# Altium schematic sheet 05_CONNECTOR_OUT.SchDoc(05_CONNECTOR_OUT)
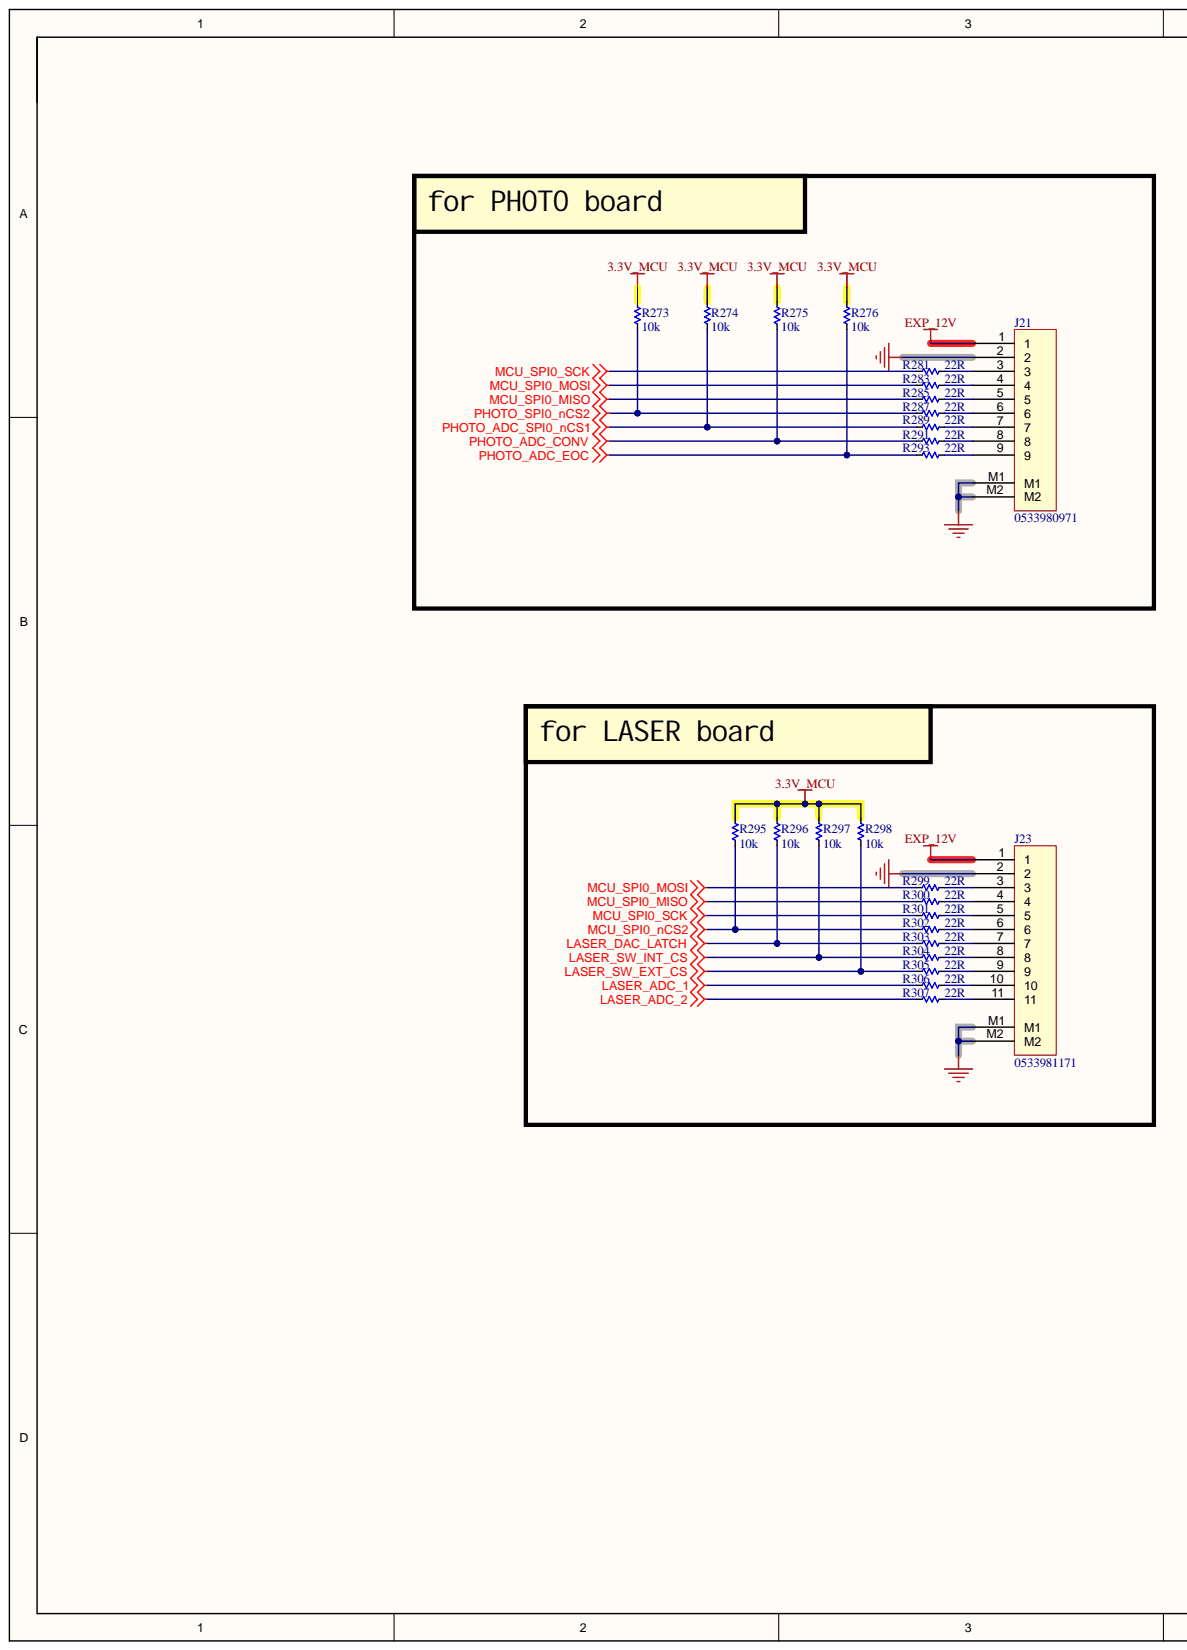
[diagram p0: 05_CONNECTOR_OUT.SchDoc, left]
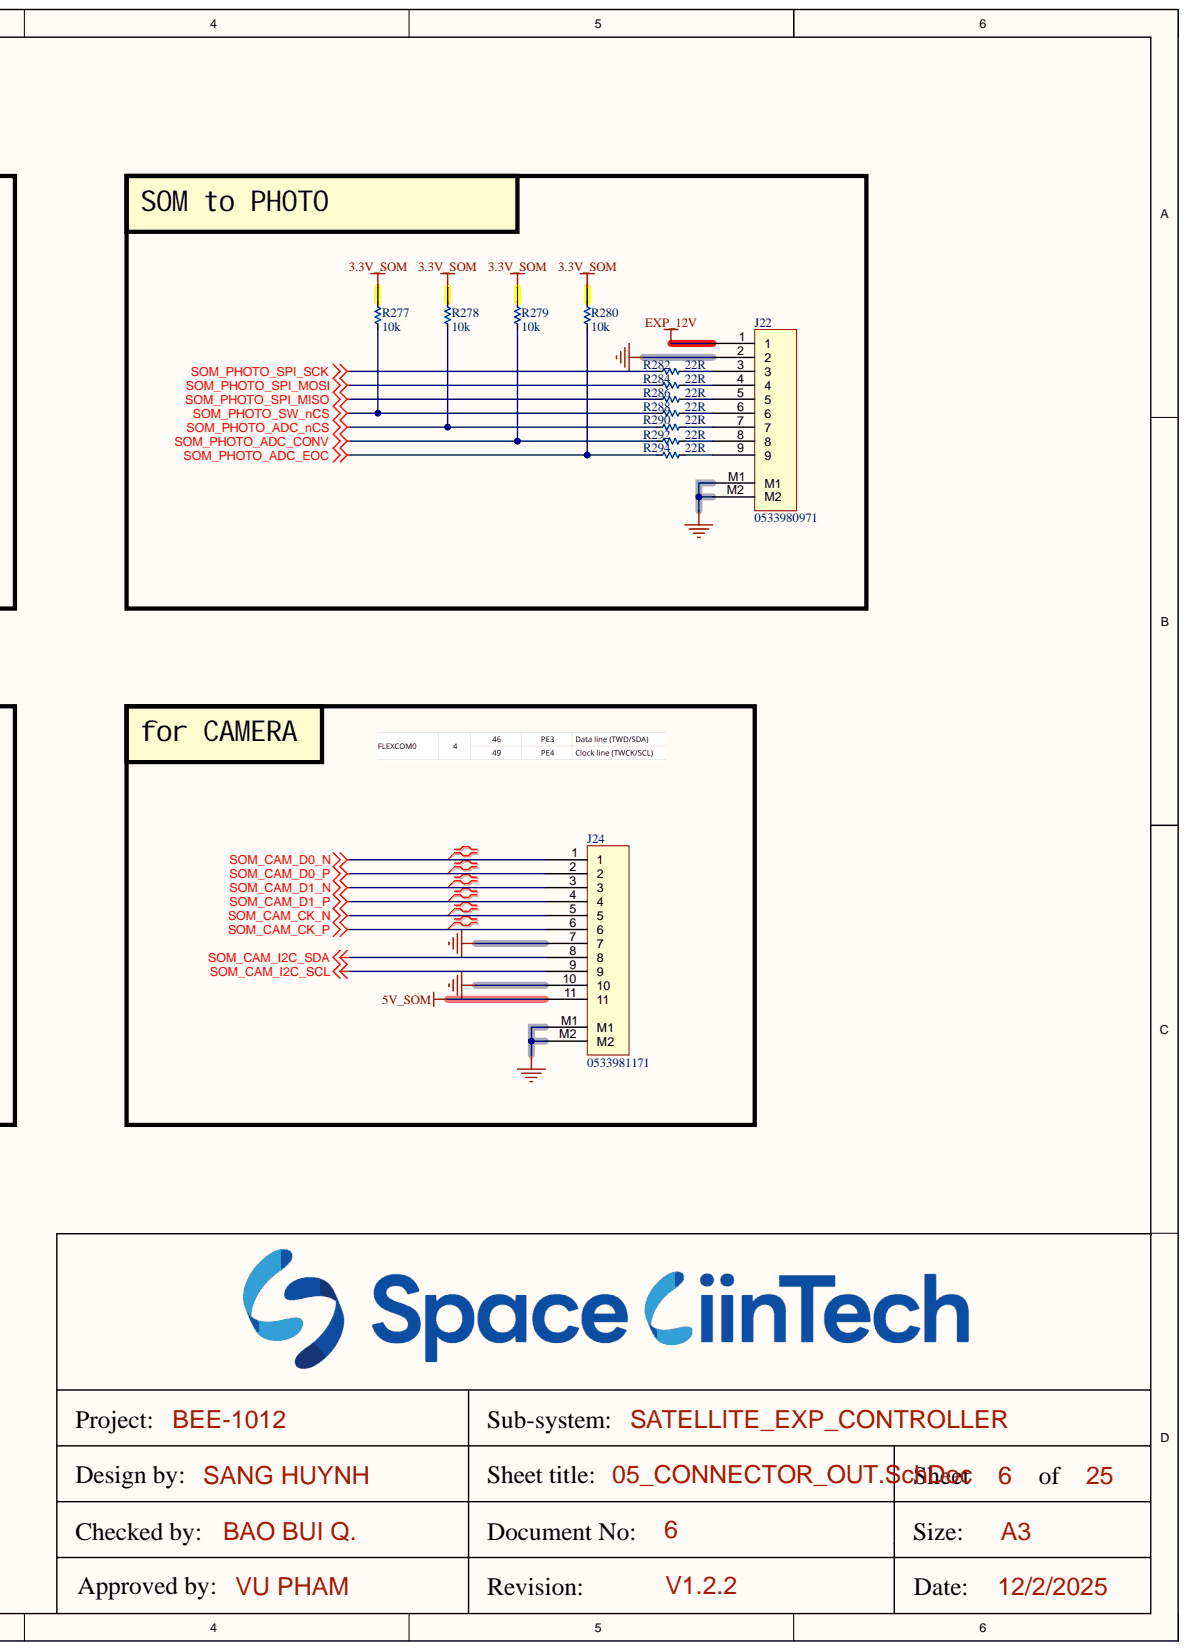
[diagram p1: 05_CONNECTOR_OUT.SchDoc, right]

## Parts
J21  0533980971  [MOLEX_0533980971]
J22  0533980971  [MOLEX_0533980971]
J23  0533981171  [MOLEX_0533981171]
J24  0533981171
R273  10k  [RES_C_1005X40]
R274  10k  [RES_C_1005X40]
R275  10k  [RES_C_1005X40]
R276  10k  [RES_C_1005X40]
R277  10k  [RES_C_1005X40]
R278  10k  [RES_C_1005X40]
R279  10k  [RES_C_1005X40]
R280  10k  [RES_C_1005X40]
R281  22R  [RES_C_1005X40]
R282  22R  [RES_C_1005X40]
R283  22R  [RES_C_1005X40]
R284  22R  [RES_C_1005X40]
R285  22R  [RES_C_1005X40]
R286  22R  [RES_C_1005X40]
R287  22R  [RES_C_1005X40]
R288  22R  [RES_C_1005X40]
R289  22R  [RES_C_1005X40]
R290  22R  [RES_C_1005X40]
R291  22R  [RES_C_1005X40]
R292  22R  [RES_C_1005X40]
R293  22R  [RES_C_1005X40]
R294  22R  [RES_C_1005X40]
R295  10k  [RES_C_1005X40]
R296  10k  [RES_C_1005X40]
R297  10k  [RES_C_1005X40]
R298  10k  [RES_C_1005X40]
R299  22R  [RES_C_1005X40]
R300  22R  [RES_C_1005X40]
R301  22R  [RES_C_1005X40]
R302  22R  [RES_C_1005X40]
R303  22R  [RES_C_1005X40]
R304  22R  [RES_C_1005X40]
R305  22R  [RES_C_1005X40]
R306  22R  [RES_C_1005X40]
R307  22R  [RES_C_1005X40]

## Nets
3.3V_MCU: R273.1 R274.1 R275.1 R276.1 R295.1 R296.1 R297.1 R298.1
3.3V_SOM: R277.1 R278.1 R279.1 R280.1
5V_SOM: J24.11
EXP_12V: J21.1 J22.1 J23.1
GND: J21.2 J21.M1 J21.M2 J22.2 J22.M1 J22.M2 J23.2 J23.M1 J23.M2 J24.7 J24.10 J24.M1 J24.M2
LASER_ADC_1: R306.1
LASER_ADC_2: R307.1
LASER_DAC_LATCH: R296.2 R303.1
LASER_SW_EXT_CS: R298.2 R305.1
LASER_SW_INT_CS: R297.2 R304.1
MCU_SPI0_MISO: R285.1 R300.1
MCU_SPI0_MOSI: R283.1 R299.1
MCU_SPI0_nCS2: R295.2 R302.1
MCU_SPI0_SCK: R281.1 R301.1
PHOTO_ADC_CONV: R275.2 R291.1
PHOTO_ADC_EOC: R276.2 R293.1
PHOTO_ADC_SPI0_nCS1: R274.2 R289.1
PHOTO_SPI0_nCS2: R273.2 R287.1
SOM_CAM_CK_N: J24.5
SOM_CAM_CK_P: J24.6
SOM_CAM_D0_N: J24.1
SOM_CAM_D0_P: J24.2
SOM_CAM_D1_N: J24.3
SOM_CAM_D1_P: J24.4
SOM_CAM_I2C_SCL: J24.9
SOM_CAM_I2C_SDA: J24.8
SOM_PHOTO_ADC_CONV: R279.2 R292.1
SOM_PHOTO_ADC_EOC: R280.2 R294.1
SOM_PHOTO_ADC_nCS: R278.2 R290.1
SOM_PHOTO_SPI_MISO: R286.1
SOM_PHOTO_SPI_MOSI: R284.1
SOM_PHOTO_SPI_SCK: R282.1
SOM_PHOTO_SW_nCS: R277.2 R288.1
NetJ21_3: J21.3 R281.2
NetJ21_4: J21.4 R283.2
NetJ21_5: J21.5 R285.2
NetJ21_6: J21.6 R287.2
NetJ21_7: J21.7 R289.2
NetJ21_8: J21.8 R291.2
NetJ21_9: J21.9 R293.2
NetJ22_3: J22.3 R282.2
NetJ22_4: J22.4 R284.2
NetJ22_5: J22.5 R286.2
NetJ22_6: J22.6 R288.2
NetJ22_7: J22.7 R290.2
NetJ22_8: J22.8 R292.2
NetJ22_9: J22.9 R294.2
NetJ23_3: J23.3 R299.2
NetJ23_4: J23.4 R300.2
NetJ23_5: J23.5 R301.2
NetJ23_6: J23.6 R302.2
NetJ23_7: J23.7 R303.2
NetJ23_8: J23.8 R304.2
NetJ23_9: J23.9 R305.2
NetJ23_10: J23.10 R306.2
NetJ23_11: J23.11 R307.2

## Library data of the parts
J21 J22 = Header 9x1_2M: Component Kind: Standard; Library Name: LABLIB.SchLib
J23 J24 = Header 11x1_2M: Component Kind: Standard; Library Name: LABLIB.SchLib
R273-R307 = _RES_B_2: RES; Component Kind: Standard; Library Name: LABLIB.SchLib
